# Altium schematic sheet Analog Sensors.SchDoc("Analog Sensors")
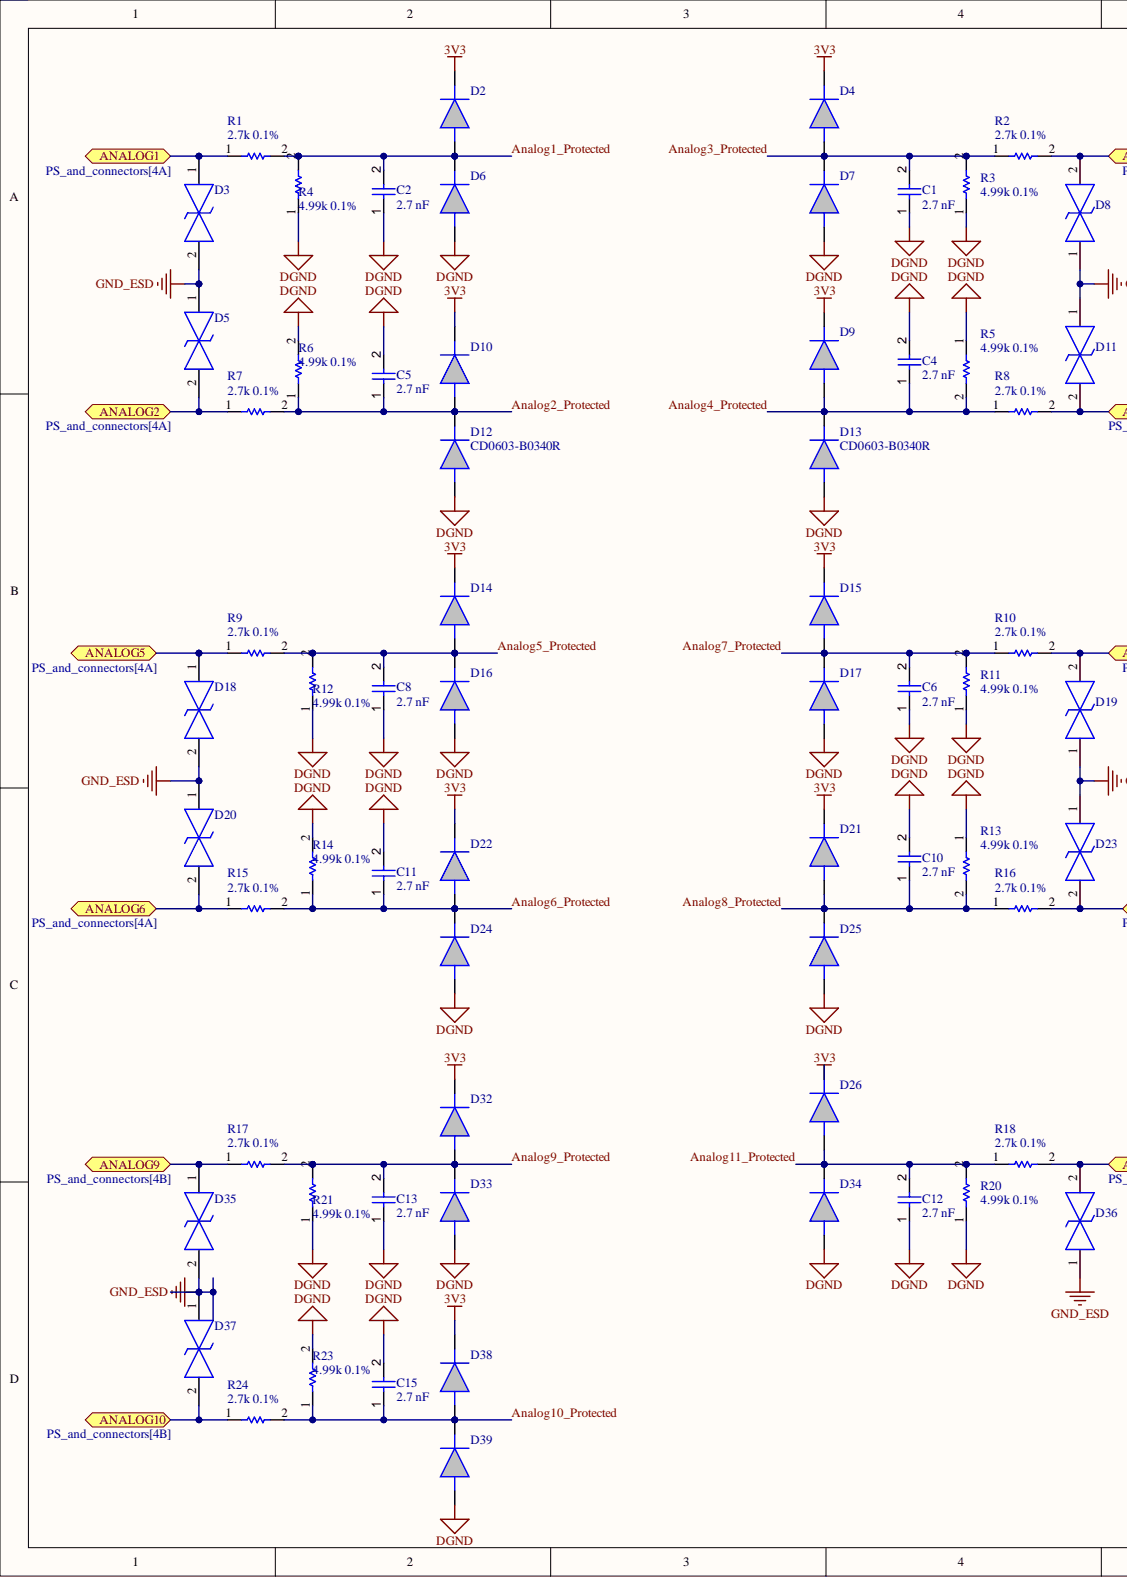
[diagram p0: Analog Sensors.SchDoc, left]
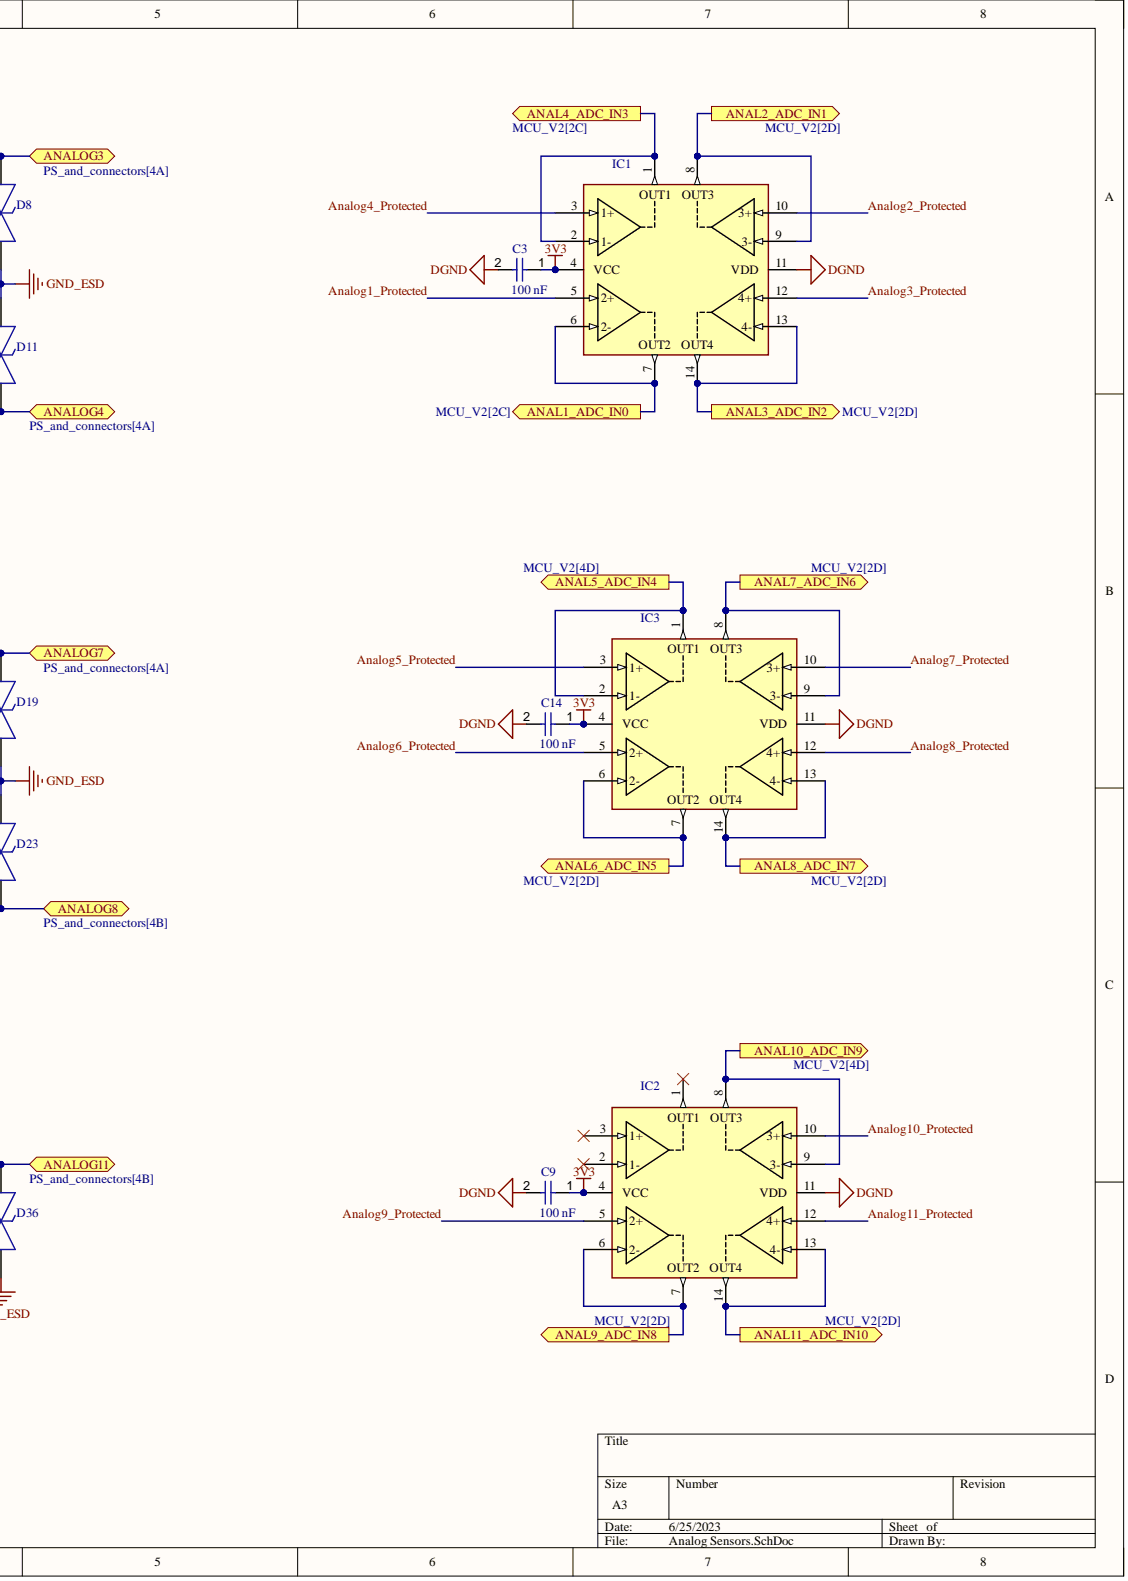
[diagram p1: Analog Sensors.SchDoc, right]

## Parts
C1  2.7 nF
C2  2.7 nF
C3  100 nF
C4  2.7 nF
C5  2.7 nF
C6  2.7 nF
C8  2.7 nF
C9  100 nF
C10  2.7 nF
C11  2.7 nF
C12  2.7 nF
C13  2.7 nF
C14  100 nF
C15  2.7 nF
D2  CD0603-B0340R
D3  SMAJ30CA-TR  (value not drawn)
D4  CD0603-B0340R
D5  SMAJ30CA-TR  (value not drawn)
D6  CD0603-B0340R
D7  CD0603-B0340R
D8  SMAJ30CA-TR  (value not drawn)
D9  CD0603-B0340R
D10  CD0603-B0340R
D11  SMAJ30CA-TR  (value not drawn)
D12  CD0603-B0340R
D13  CD0603-B0340R
D14  CD0603-B0340R
D15  CD0603-B0340R
D16  CD0603-B0340R
D17  CD0603-B0340R
D18  SMAJ30CA-TR  (value not drawn)
D19  SMAJ30CA-TR  (value not drawn)
D20  SMAJ30CA-TR  (value not drawn)
D21  CD0603-B0340R
D22  CD0603-B0340R
D23  SMAJ30CA-TR  (value not drawn)
D24  CD0603-B0340R
D25  CD0603-B0340R
D26  CD0603-B0340R
D32  CD0603-B0340R
D33  CD0603-B0340R
D34  CD0603-B0340R
D35  SMAJ30CA-TR  (value not drawn)
D36  SMAJ30CA-TR  (value not drawn)
D37  SMAJ30CA-TR  (value not drawn)
D38  CD0603-B0340R
D39  CD0603-B0340R
IC1  TSV324IDT  (value not drawn)
IC2  TSV324IDT  (value not drawn)
IC3  TSV324IDT  (value not drawn)
R1  2.7k 0.1%
R2  2.7k 0.1%
R3  4.99k 0.1%
R4  4.99k 0.1%
R5  4.99k 0.1%
R6  4.99k 0.1%
R7  2.7k 0.1%
R8  2.7k 0.1%
R9  2.7k 0.1%
R10  2.7k 0.1%
R11  4.99k 0.1%
R12  4.99k 0.1%
R13  4.99k 0.1%
R14  4.99k 0.1%
R15  2.7k 0.1%
R16  2.7k 0.1%
R17  2.7k 0.1%
R18  2.7k 0.1%
R20  4.99k 0.1%
R21  4.99k 0.1%
R23  4.99k 0.1%
R24  2.7k 0.1%

## Ports
ANAL1_ADC_IN0, ANAL2_ADC_IN1, ANAL3_ADC_IN2, ANAL4_ADC_IN3, ANAL5_ADC_IN4, ANAL6_ADC_IN5, ANAL7_ADC_IN6, ANAL8_ADC_IN7, ANAL9_ADC_IN8, ANAL10_ADC_IN9, ANAL11_ADC_IN10, ANALOG1, ANALOG2, ANALOG3, ANALOG4, ANALOG5, ANALOG6, ANALOG7, ANALOG8, ANALOG9, ANALOG10, ANALOG11

## Nets
3V3: C3.1 C9.1 C14.1 D2.1 D4.1 D9.1 D10.1 D14.1 D15.1 D21.1 D22.1 D26.1 D32.1 D38.1 IC1.4(VCC) IC2.4(VCC) IC3.4(VCC)
DGND: C1.1 C2.1 C3.2 C4.2 C5.2 C6.1 C8.1 C9.2 C10.2 C11.2 C12.1 C13.1 C14.2 C15.2 D6.2 D7.2 D12.2 D13.2 D16.2 D17.2 D24.2 D25.2 D33.2 D34.2 D39.2 IC1.11(VDD) IC2.11(VDD) IC3.11(VDD) R3.1 R4.1 R5.1 R6.2 R11.1 R12.1 R13.1 R14.2 R20.1 R21.1 R23.2
GND_ESD: D3.2 D5.1 D8.1 D11.1 D18.2 D19.1 D20.1 D23.1 D35.2 D36.1 D37.1
ANAL1_ADC_IN0: IC1.6(2-) IC1.7 ; port ANAL1_ADC_IN0
ANAL2_ADC_IN1: IC1.8 IC1.9(3-) ; port ANAL2_ADC_IN1
ANAL3_ADC_IN2: IC1.13(4-) IC1.14 ; port ANAL3_ADC_IN2
ANAL4_ADC_IN3: IC1.1 IC1.2(1-) ; port ANAL4_ADC_IN3
ANAL5_ADC_IN4: IC3.1 IC3.2(1-) ; port ANAL5_ADC_IN4
ANAL6_ADC_IN5: IC3.6(2-) IC3.7 ; port ANAL6_ADC_IN5
ANAL7_ADC_IN6: IC3.8 IC3.9(3-) ; port ANAL7_ADC_IN6
ANAL8_ADC_IN7: IC3.13(4-) IC3.14 ; port ANAL8_ADC_IN7
ANAL9_ADC_IN8: IC2.6(2-) IC2.7 ; port ANAL9_ADC_IN8
ANAL10_ADC_IN9: IC2.8 IC2.9(3-) ; port ANAL10_ADC_IN9
ANAL11_ADC_IN10: IC2.13(4-) IC2.14 ; port ANAL11_ADC_IN10
ANALOG1: D3.1 R1.1 ; port ANALOG1
Analog1_Protected: C2.2 D2.2 D6.1 IC1.5(2+) R1.2 R4.2
ANALOG2: D5.2 R7.1 ; port ANALOG2
Analog2_Protected: C5.1 D10.2 D12.1 IC1.10(3+) R6.1 R7.2
ANALOG3: D8.2 R2.2 ; port ANALOG3
Analog3_Protected: C1.2 D4.2 D7.1 IC1.12(4+) R2.1 R3.2
ANALOG4: D11.2 R8.2 ; port ANALOG4
Analog4_Protected: C4.1 D9.2 D13.1 IC1.3(1+) R5.2 R8.1
ANALOG5: D18.1 R9.1 ; port ANALOG5
Analog5_Protected: C8.2 D14.2 D16.1 IC3.3(1+) R9.2 R12.2
ANALOG6: D20.2 R15.1 ; port ANALOG6
Analog6_Protected: C11.1 D22.2 D24.1 IC3.5(2+) R14.1 R15.2
ANALOG7: D19.2 R10.2 ; port ANALOG7
Analog7_Protected: C6.2 D15.2 D17.1 IC3.10(3+) R10.1 R11.2
ANALOG8: D23.2 R16.2 ; port ANALOG8
Analog8_Protected: C10.1 D21.2 D25.1 IC3.12(4+) R13.2 R16.1
ANALOG9: D35.1 R17.1 ; port ANALOG9
Analog9_Protected: C13.2 D32.2 D33.1 IC2.5(2+) R17.2 R21.2
ANALOG10: D37.2 R24.1 ; port ANALOG10
Analog10_Protected: C15.1 D38.2 D39.1 IC2.10(3+) R23.1 R24.2
ANALOG11: D36.2 R18.2 ; port ANALOG11
Analog11_Protected: C12.2 D26.2 D34.1 IC2.12(4+) R18.1 R20.2

Not connected: IC2 1 2(1-) 3(1+)

## Library data of the parts
C1 C2 C4-C6 C8 C10-C13 C15 = CMP-00009-1: CL21B272KBANNNC; Component Kind: Standard; Library Name: EMEA- Squadra Corse PoliTO-  Politecnico di Torino
C3 C9 C14 = CMP-00009-1: VJ0805Y104MXATW1BC; Component Kind: Standard; Library Name: EMEA- Squadra Corse PoliTO-  Politecnico di Torino
D2 D4 D6 D7 D9 D10 D12-D17 D21 D22 D24-D26 D32-D34 D38 D39 = CMP-110-000000-5: Bourns 40V 300mA, Diode, 2-Pin 0603 CD0603-B0340R; Geometry.Height: 0.8mm; Component Kind: Standard; Datasheet Link: https://www.bourns.com/docs/product-datasheets/cd0603-b.pdf; Design Item ID: CD0603-B0340R; Manufacturer_Name: Bourns; Manufacturer_Part_Number: CD0603-B0340R; Mouser Part Number: 652-CD0603-B0340R; Mouser Price/Stock: https://www.mouser.co.uk/ProductDetail/Bourns/CD0603-B0340R?qs=xhbEVWpZdWee9K23%252B3DSjQ%3D%3D; Source: CD0603-B0340R.SchLib; Library Name: EMEA- Squadra Corse PoliTO-  Politecnico di Torino
D3 D5 D8 D11 D18-D20 D23 D35-D37 = CMP-004-000005-1: TVS Diode Bi-Directional SMAJ30CA-TR 64.3V; Manufacturer_Name: STMicroelectronics; Arrow Part Number: SMAJ30CA-TR; Arrow Price/Stock: https://www.arrow.com/en/products/smaj30ca-tr/stmicroelectronics?region=nac; Component Kind: Standard; Datasheet Link: https://www.st.com/resource/en/datasheet/smaj10ca.pdf; Design Item ID: SMAJ30CA-TR; Height: 2.65mm; Manufacturer_Part_Number: SMAJ30CA-TR; Mouser Part Number: 511-SMAJ30CA-TR; Mouser Price/Stock: https://www.mouser.co.uk/ProductDetail/STMicroelectronics/SMAJ30CA-TR?qs=0TJjK1waviDY0EkLaKH12g%3D%3D; Source: SamacSys.SchLib; Library Name: EMEA- Squadra Corse PoliTO-  Politecnico di Torino
IC1-IC3 = CMP-007-000004-2: Manufacturer_Name: STMicroelectronics; Arrow Part Number: TSV324IDT; Arrow Price/Stock: https://www.arrow.com/en/products/tsv324idt/stmicroelectronics; Component Kind: Standard; Datasheet Link: http://www.st.com/st-web-ui/static/active/en/resource/technical/document/datasheet/CD00063292.pdf; Description: TSV324IDT; Design Item ID: TSV324IDT; Height: 1.75mm; Manufacturer_Part_Number: TSV324IDT; Mouser Part Number: 511-TSV324IDT; Mouser Price/Stock: https://www.mouser.com/Search/Refine.aspx?Keyword=511-TSV324IDT; Source: SamacSys.SchLib; Library Name: EMEA- Squadra Corse PoliTO-  Politecnico di Torino
R1 R2 R7-R10 R15-R18 R24 = CMP-009-00179-4: RT0603BRE072K7L; Tech: SMD; Component Kind: Standard; ComponentLink1Description: Website; Package: 0603; Library Name: EMEA- Squadra Corse PoliTO-  Politecnico di Torino
R3-R6 R11-R14 R20 R21 R23 = CMP-009-00179-4: RT0603BRD074K99L; Tech: SMD; Component Kind: Standard; ComponentLink1Description: Website; Package: 0603; Library Name: EMEA- Squadra Corse PoliTO-  Politecnico di Torino
